# Altium schematic sheet Three_Phase_Inverter.SchDoc
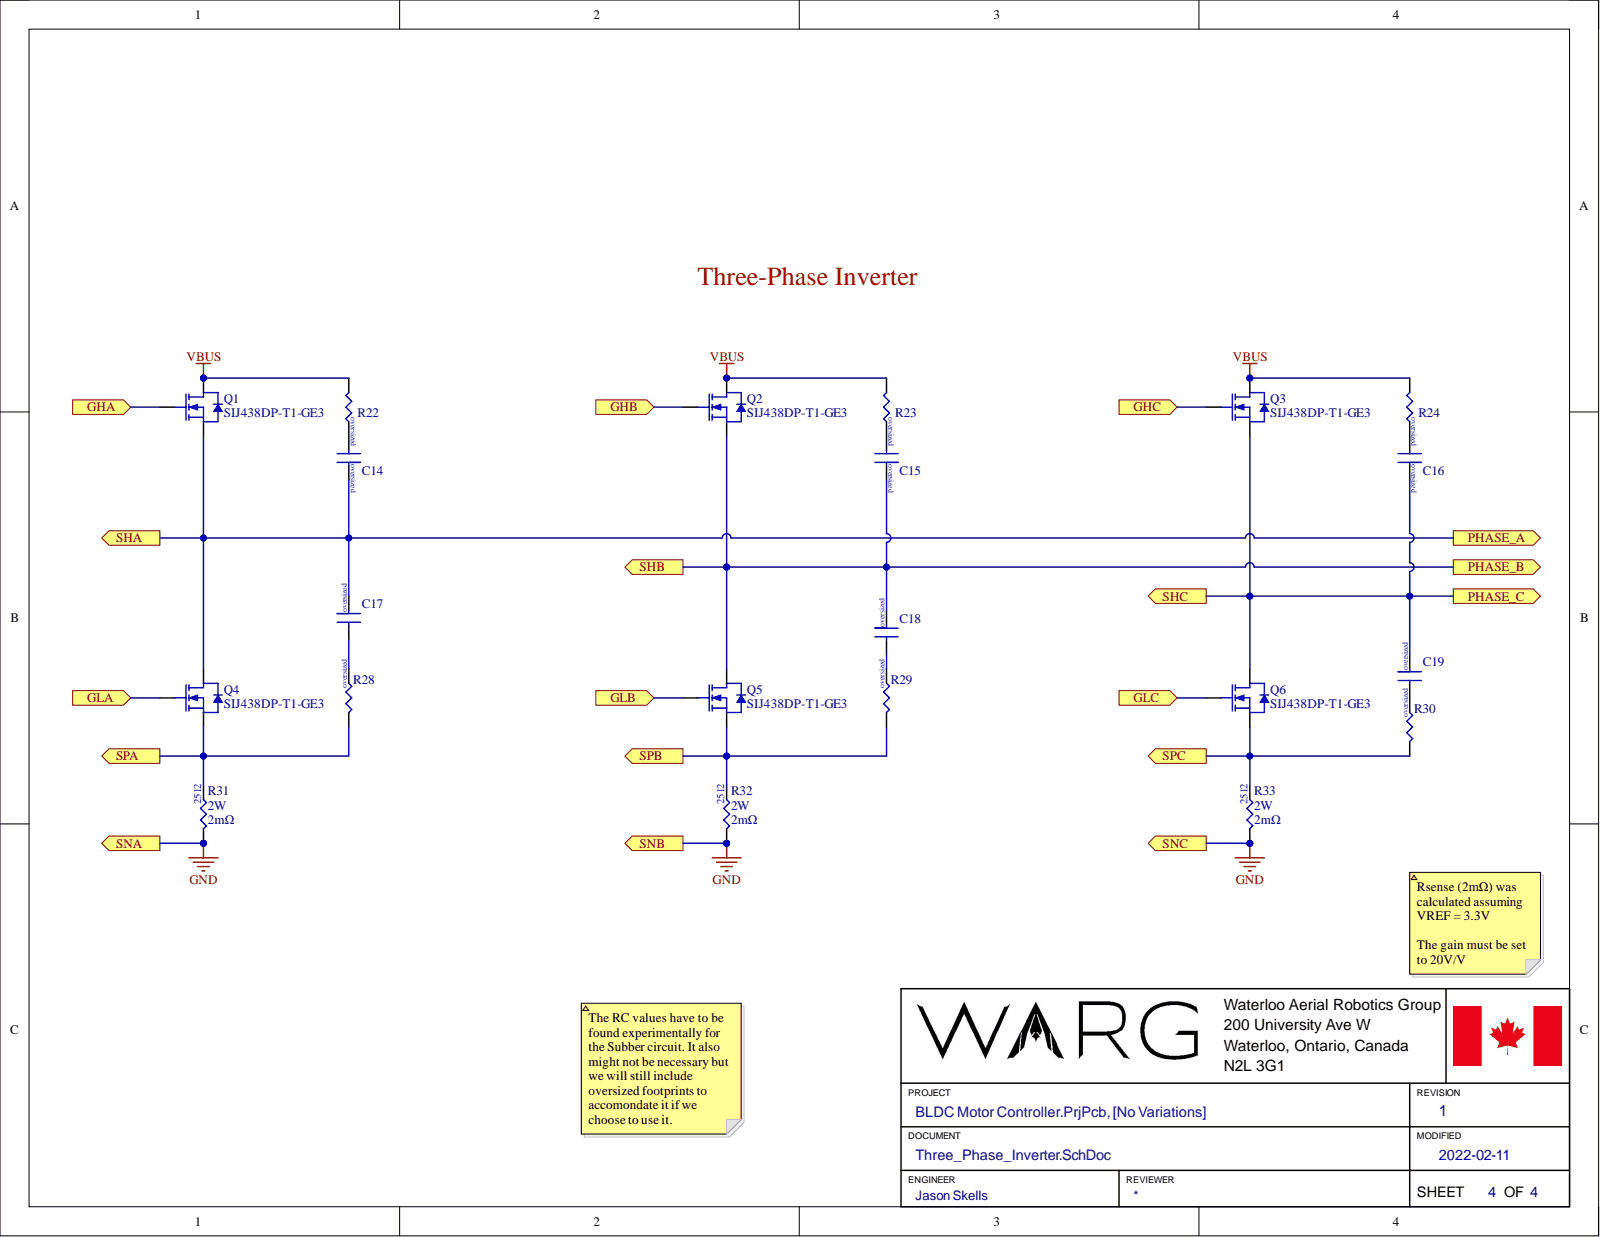
[diagram p0: Three_Phase_Inverter.SchDoc]

## Parts
C14  EMPTY PART FOR RC SNUBBER CIRCUIT  [RES/CAP_OVERSIZED]  (value not drawn)
C15  EMPTY PART FOR RC SNUBBER CIRCUIT  [RES/CAP_OVERSIZED]  (value not drawn)
C16  EMPTY PART FOR RC SNUBBER CIRCUIT  [RES/CAP_OVERSIZED]  (value not drawn)
C17  EMPTY PART FOR RC SNUBBER CIRCUIT  [RES/CAP_OVERSIZED]  (value not drawn)
C18  EMPTY PART FOR RC SNUBBER CIRCUIT  [RES/CAP_OVERSIZED]  (value not drawn)
C19  EMPTY PART FOR RC SNUBBER CIRCUIT  [RES/CAP_OVERSIZED]  (value not drawn)
Q1  SIJ438DP-T1-GE3  [NMOS_PPAK_SO8]
Q2  SIJ438DP-T1-GE3  [NMOS_PPAK_SO8]
Q3  SIJ438DP-T1-GE3  [NMOS_PPAK_SO8]
Q4  SIJ438DP-T1-GE3  [NMOS_PPAK_SO8]
Q5  SIJ438DP-T1-GE3  [NMOS_PPAK_SO8]
Q6  SIJ438DP-T1-GE3  [NMOS_PPAK_SO8]
R22  RES_OVERSIZED  [RES/CAP_OVERSIZED]  (value not drawn)
R23  RES_OVERSIZED  [RES/CAP_OVERSIZED]  (value not drawn)
R24  RES_OVERSIZED  [RES/CAP_OVERSIZED]  (value not drawn)
R28  RES_OVERSIZED  [RES/CAP_OVERSIZED]  (value not drawn)
R29  RES_OVERSIZED  [RES/CAP_OVERSIZED]  (value not drawn)
R30  RES_OVERSIZED  [RES/CAP_OVERSIZED]  (value not drawn)
R31  Case Code (Imperial): 2512  Power Rating: 2W  Resistance: 2mΩ  [RES_SHUNT_2512]  (hidden comment: RES_2512)
R32  Case Code (Imperial): 2512  Power Rating: 2W  Resistance: 2mΩ  [RES_SHUNT_2512]  (hidden comment: RES_2512)
R33  Case Code (Imperial): 2512  Power Rating: 2W  Resistance: 2mΩ  [RES_SHUNT_2512]  (hidden comment: RES_2512)

## Ports
GHA, GHB, GHC, GLA, GLB, GLC, PHASE_A, PHASE_B, PHASE_C, SHA, SHB, SHC, SNA, SNB, SNC, SPA, SPB, SPC

## Nets
VBUS: Q1.1 Q2.1 Q3.1 R22.1 R23.1 R24.1
GHA: Q1.2 ; port GHA
GHB: Q2.2 ; port GHB
GHC: Q3.2 ; port GHC
GLA: Q4.2 ; port GLA
GLB: Q5.2 ; port GLB
GLC: Q6.2 ; port GLC
GND: R31.1 R32.1 R33.1 ; port SNA, port SNB, port SNC
PHASE_A: C14.2 C17.2 Q1.3 Q4.1 ; port PHASE_A, port SHA
PHASE_B: C15.2 C18.2 Q2.3 Q5.1 ; port PHASE_B, port SHB
PHASE_C: C16.2 C19.2 Q3.3 Q6.1 ; port PHASE_C, port SHC
SPA: Q4.3 R28.1 R31.2 ; port SPA
SPB: Q5.3 R29.1 R32.2 ; port SPB
SPC: Q6.3 R30.1 R33.2 ; port SPC
NetC14_1: C14.1 R22.2
NetC15_1: C15.1 R23.2
NetC16_1: C16.1 R24.2
NetC17_1: C17.1 R28.2
NetC18_1: C18.1 R29.2
NetC19_1: C19.1 R30.2

## Library data of the parts
C14-C19 = CAP_OVERSIZED: EMPTY PART FOR RC SNUBBER CIRCUIT (I just wanted the symbol and footprint to place); Component Kind: Standard; Library Name: motor_controller_schem_lib.SchLib
Q1-Q6 = SIJ438DP-T1-GE3: MOSFET N-CHANNEL 40V 1.35 mOhm PPAK SO-8; Manufacturer: Vishay Siliconix; Continuous Drain Current (ID): 80A; Input Capacitance: 9.4nF; Max Power Dissipation: 69.4W; Rds On Max: 1.35mR; Category: MOSFETs; Component Kind: Standard; ComponentLink1Description: http://www.vishay.com/docs/69684/sij438dp.pdf?src-supplier=Digi-Key; ComponentLink1URL: http://www.vishay.com/docs/69684/sij438dp.pdf?src-supplier=Digi-Key; Drain to Source Voltage (Vdss): 40V; Library Name: motor_controller_schem_lib.SchLib; Manufacturer Part Number: SIJ438DP-T1-GE3; Max Operating Temperature: 150°C; Min Operating Temperature: -55°C; Mount: Surface Mount; Packaging: Cut Tape; Pricing 1: 1=1.81000, 10=1.62100, 100=1.26370, 500=1.04396, 1000=0.82418 (USD); RoHS: Yes; Stock 1: 1338; Supplier 1: Digi-Key; Supplier Part Number 1: SIJ438DP-T1-GE3CT-ND
R22-R24 R28-R30 = RES_OVERSIZED: EMPTY PART FOR RC SNUBBER CIRCUIT (I just wanted the symbol and footprint to place); Component Kind: Standard; Library Name: motor_controller_schem_lib.SchLib
R31-R33 = RES_2512 (current sense): SMD Current Sense Resistors, TLR Series, 0.002 ohm, 2 W, - 1%, 2512 [6432 Metric]; Manufacturer: TE Connectivity; Manufacturer Part Number: TLR3A20DR002FTDG; Number of Resistors: 1; Temperature Coefficient: 50ppm/°C; Case Code (Metric): 6432; Case/Package: 2512; Category: Chip SMD Resistors; Component Kind: Standard; ComponentLink1Description: https://datasheet.ciiva.com/25608/0900766b81196d57-25608892.pdf?src-supplier=RSComponents; ComponentLink1URL: https://datasheet.ciiva.com/25608/0900766b81196d57-25608892.pdf?src-supplier=RSComponents; ComponentLink2Description: https://datasheet.ciiva.com/7823/1610596-7823541.pdf?src-supplier=Element14; ComponentLink2URL: https://datasheet.ciiva.com/7823/1610596-7823541.pdf?src-supplier=Element14; ComponentLink3Description: https://datasheet.ciiva.com/46439/1610596-46439141.pdf?src-supplier=Element14; ComponentLink3URL: https://datasheet.ciiva.com/46439/1610596-46439141.pdf?src-supplier=Element14; Depth: 3.2mm; ELV: Compliant; Height: 0.6mm; Length: 6.4mm; Library Name: motor_controller_schem_lib.SchLib; Max Operating Temperature: 170°C; Min Operating Temperature: -55°C; Mount: Surface Mount; Number of Terminations: 2; Packaging: Tape and Reel; Pricing 1: 1=0.90000, 10=0.78100, 25=0.68600, 50=0.62680, 100=0.54410, 250=0.47312, 500=0.39268, 1000=0.32172 (USD); REACH SVHC: No SVHC; Resistance Tolerance: 1%; Resistor Type: Metal Strip; RoHS: Yes; RoHS Compliant: Yes; Series: TLR; Stock 1: 11294; Supplier 1: Digi-Key; Supplier Part Number 1: A129566CT-ND; Technology: METAL STRIP; Termination: Solder; Tolerance: 1%
